# Altium schematic sheet EVB-NORA-B2_SCHEM_0004_Connectors_Memory.SchDoc(EVB-NORA-B2_SCHEM_0004_Connectors_Memory)
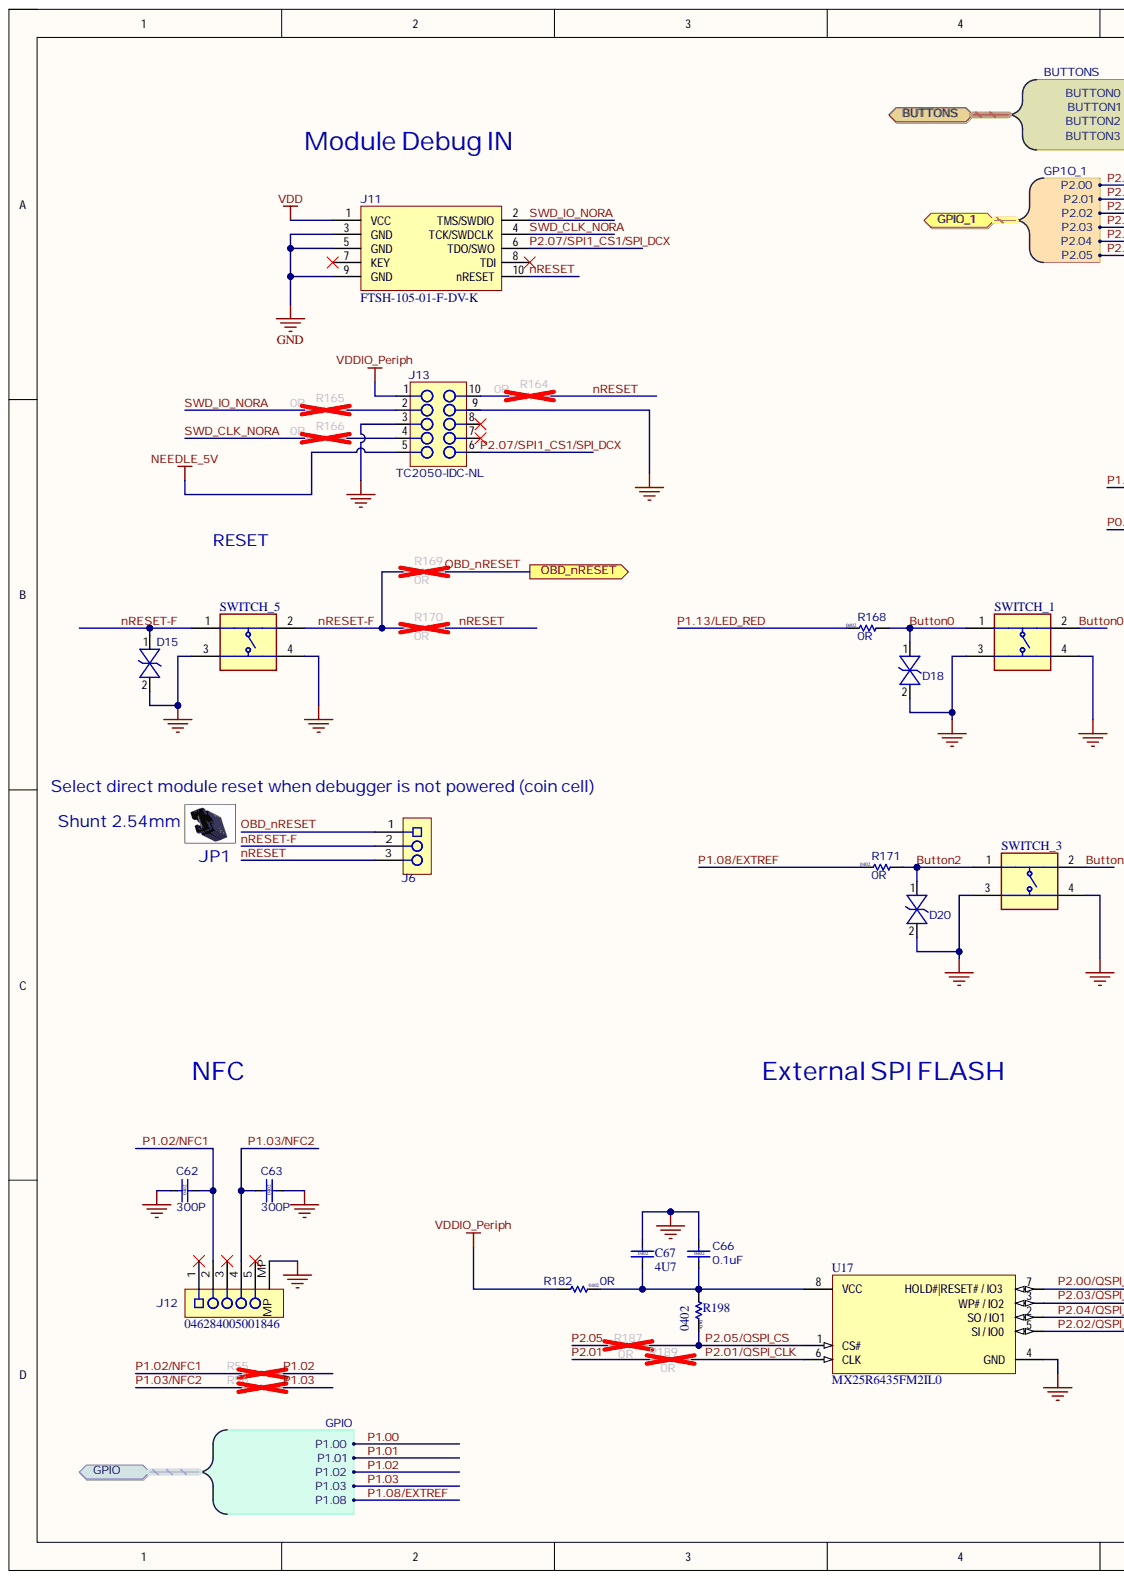
[diagram p0: EVB-NORA-B2_SCHEM_0004_Connectors_Memory.SchDoc, left]
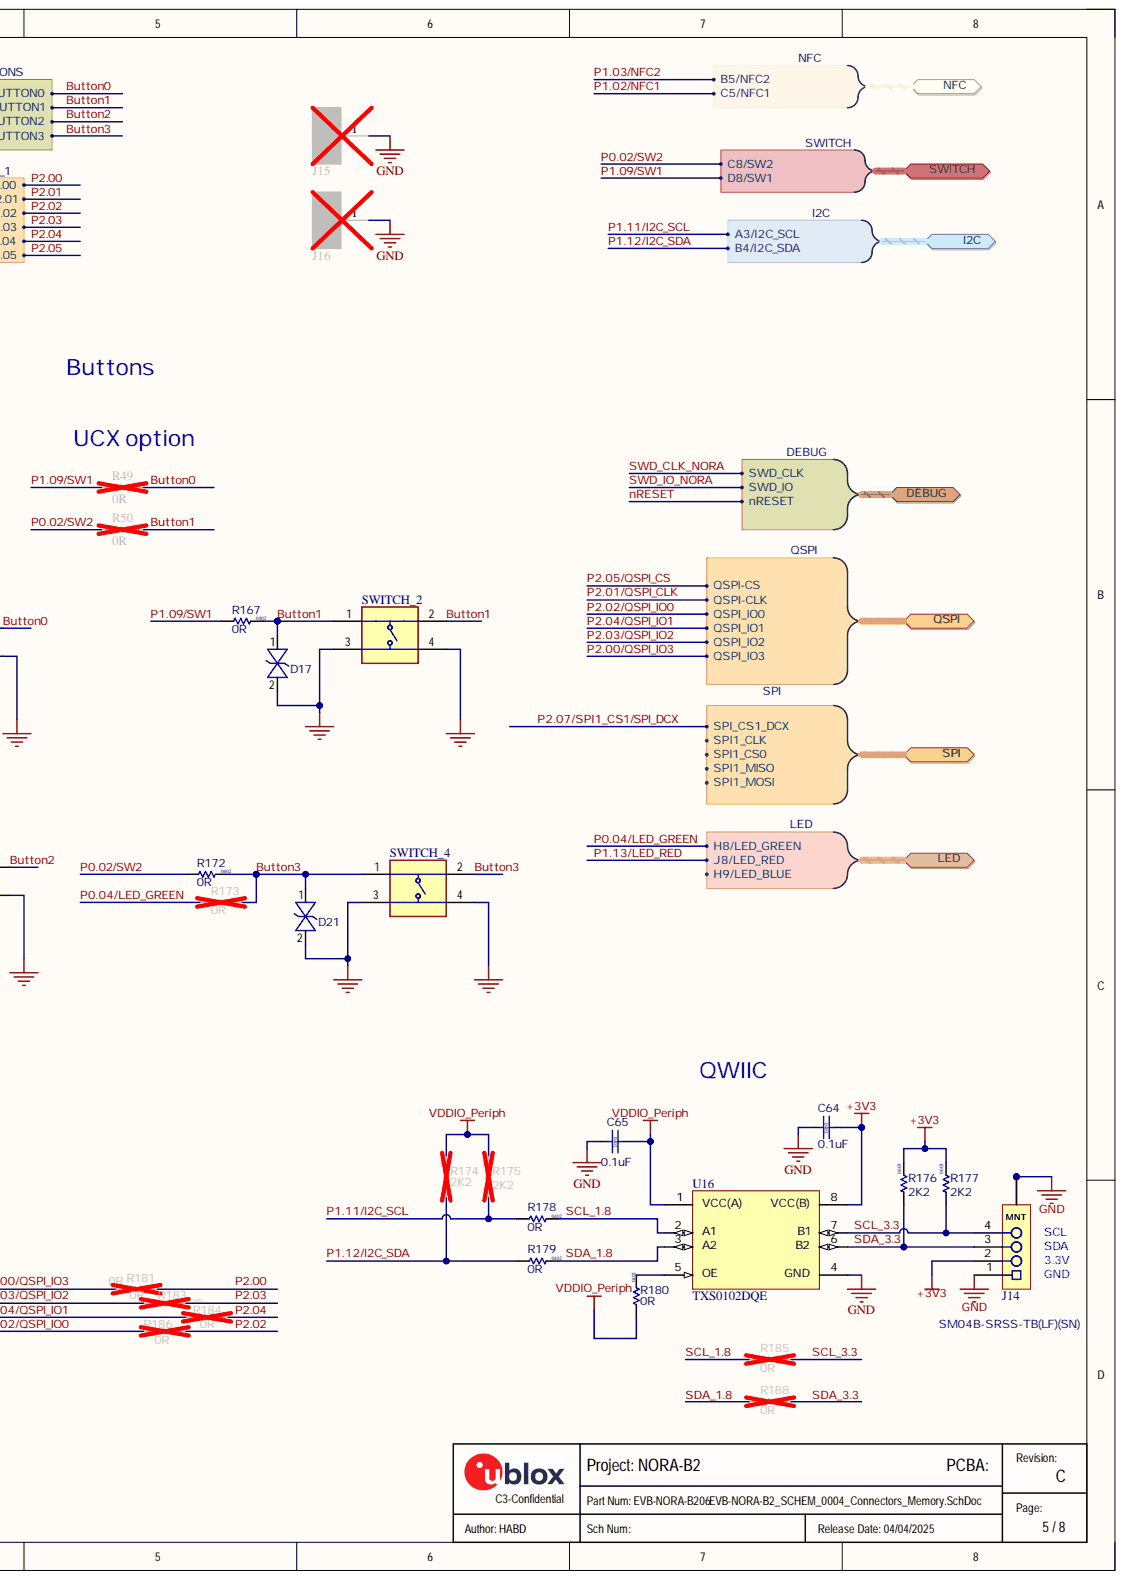
[diagram p1: EVB-NORA-B2_SCHEM_0004_Connectors_Memory.SchDoc, right]

## Parts
C62  value 300P  Size: 0402  [Cap 0402 HD]  (hidden comment: UBXH01-0000594)
C63  value 300P  Size: 0402  [Cap 0402 HD]  (hidden comment: UBXH01-0000594)
C64  value 0.1uF  Size: 0402  [Cap 0402 HD]  (hidden comment: 120-000160.1uF)
C65  value 0.1uF  Size: 0402  [Cap 0402 HD]  (hidden comment: 120-000160.1uF)
C66  value 0.1uF  Size: 0402  [Cap 0402 HD]  (hidden comment: 120-00016)
C67  value 4U7  Size: 0402  [Cap 0402 HD]
D15  110-00019  [SOD-923]  (value not drawn)
D17  110-00019  [SOD-923]  (value not drawn)
D18  110-00019  [SOD-923]  (value not drawn)
D20  110-00019  [SOD-923]  (value not drawn)
D21  110-00019  [SOD-923]  (value not drawn)
J6  UBXH04-0000792  [HDR-0.1P-3Pin-1Row-SMT]  (value not drawn)
J11  Manufacturer P/N: FTSH-105-01-F-DV-K  [HDR.050P-10Pin-2x5-SMT_Key]  (hidden comment: 130-00099)
J12  value 046284005001846  Manufacturer P/N: 046284005001846  [AVX/Kyocera - 046284005001846]  (hidden comment: UBXH04-0000798)
J13  TC2050-IDC-NL  [TC2050-IDC-NL]  (no BOM)
J14  Manufacturer Part Number 1: SM04B-SRSS-TB(LF)(SN)  [SM04B-SRSS-TB]  (hidden comment: UBXH04-0000797)
J15  ="Part Number"  [Harwin_0_MFG]
J16  ="Part Number"  [Harwin_0_MFG]
R49  value 0R  Size: 0402  [RES_0402_1100Lx600Wx400H_500x600Pad_400G_FLX]
R50  value 0R  Size: 0402  [RES_0402_1100Lx600Wx400H_500x600Pad_400G_FLX]
R55  Size: 0402  [RES 0402 HD]
R56  Size: 0402  [RES 0402 HD]
R164  value 0R  Size: 0402  [RES 0402 HD]
R165  value 0R  Size: 0402  [RES 0402 HD]
R166  value 0R  Size: 0402  [RES 0402 HD]
R167  value 0R  Size: 0402  [RES 0402 HD]  (hidden comment: UBXH18-0003002)
R168  value 0R  Size: 0402  [RES 0402 HD]
R169  value 0R  Size: 0402  [RES 0402 HD]
R170  value 0R  Size: 0402  [RES 0402 HD]
R171  value 0R  Size: 0402  [RES 0402 HD]  (hidden comment: UBXH18-0003002)
R172  value 0R  Size: 0402  [RES 0402 HD]
R173  value 0R  Size: 0402  [RES 0402 HD]  (hidden comment: UBXH18-0003002)
R174  value 2K2  Size: 0603  [RES_0603_1700Lx950Wx600H_600x1000Pad_800G_FLX]
R175  value 2K2  Size: 0603  [RES_0603_1700Lx950Wx600H_600x1000Pad_800G_FLX]
R176  value 2K2  Size: 0603  [RES_0603_1700Lx950Wx600H_600x1000Pad_800G_FLX]
R177  value 2K2  Size: 0603  [RES_0603_1700Lx950Wx600H_600x1000Pad_800G_FLX]
R178  value 0R  Size: 0402  [RES 0402 HD]
R179  value 0R  Size: 0402  [RES 0402 HD]
R180  value 0R  Size: 0402  [RES 0402 HD]
R181  value 0R  Size: 0402  [RES 0402 HD]
R182  value 0R  Size: 0402  [RES 0402 HD]
R183  value 0R  Size: 0402  [RES 0402 HD]
R184  value 0R  Size: 0402  [RES 0402 HD]
R185  value 0R  Size: 0402  [RES 0402 HD]
R186  value 0R  Size: 0402  [RES 0402 HD]
R187  value 0R  Size: 0402  [RES 0402 HD]
R188  value 0R  Size: 0402  [RES 0402 HD]
R189  value 0R  Size: 0402  [RES 0402 HD]
R198  Case/Package: 0x0y  Size: 0402  [RES 0402 HD]  (hidden comment: UBXH18-0000005)
SWITCH_1  (no value)  [PTS810SJG250SMTRLFS]
SWITCH_2  (no value)  [PTS810SJG250SMTRLFS]
SWITCH_3  (no value)  [PTS810SJG250SMTRLFS]
SWITCH_4  (no value)  [PTS810SJG250SMTRLFS]
SWITCH_5  (no value)  [PTS810SJG250SMTRLFS]
U16  value TXS0102DQE  Manufacturer P/N: TXS0102DQE  [DQE0008A]
U17  Manufacturer P/N: MX25R6435FM2IL0  [SOIC-8]

## Ports
BUTTONS, BUTTONS.BUTTON0, BUTTONS.BUTTON1, BUTTONS.BUTTON2, BUTTONS.BUTTON3, DEBUG, DEBUG.nRESET, DEBUG.SWD_CLK, DEBUG.SWD_IO, GPIO, GPIO.P1.00, GPIO.P1.01, GPIO.P1.02, GPIO.P1.03, GPIO.P1.08, GPIO_1, GPIO_1.P2.00, GPIO_1.P2.01, GPIO_1.P2.02, GPIO_1.P2.03, GPIO_1.P2.04, GPIO_1.P2.05, I2C, I2C.A3/I2C_SCL, I2C.B4/I2C_SDA, LED, LED.H8/LED_GREEN, LED.H9/LED_BLUE, LED.J8/LED_RED, NFC, NFC.B5/NFC2, NFC.C5/NFC1, OBD_nRESET, QSPI, QSPI.QSPI-CLK, QSPI.QSPI-CS, QSPI.QSPI_IO0, QSPI.QSPI_IO1, QSPI.QSPI_IO2, QSPI.QSPI_IO3, SPI, SPI.SPI1_CLK, SPI.SPI1_CS0, SPI.SPI1_MISO, SPI.SPI1_MOSI, SPI.SPI_CS1_DCX, SWITCH, SWITCH.C8/SW2, SWITCH.D8/SW1

## Nets
+3V3: C64.1 J14.2 R176.2 R177.2 U16.8(VCC(B))
GND: C62.1 C63.2 C64.2 C65.2 C66.1 C67.1 D15.2 D17.2 D18.2 D20.2 D21.2 J11.3(GND) J11.5(GND) J11.9(GND) J12.MP J13.3 J13.9 J14.1 J14.M1 J14.M2 J15.1 J16.1 SWITCH_1.3 SWITCH_1.4 SWITCH_2.3 SWITCH_2.4 SWITCH_3.3 SWITCH_3.4 SWITCH_4.3 SWITCH_4.4 SWITCH_5.3 SWITCH_5.4 U16.4(GND) U17.4(GND)
NEEDLE_5V: J13.5
VDD: J11.1(VCC)
VDDIO_Periph: C65.1 J13.1 R174.2 R175.2 R180.2 R182.1 U16.1(VCC(A))
Button0: D18.1 R49.2 R168.1 SWITCH_1.1 SWITCH_1.2 ; port BUTTONS
Button1: D17.1 R50.2 R167.1 SWITCH_2.1 SWITCH_2.2 ; port BUTTONS
Button2: D20.1 R171.1 SWITCH_3.1 SWITCH_3.2 ; port BUTTONS
Button3: D21.1 R172.1 R173.1 SWITCH_4.1 SWITCH_4.2 ; port BUTTONS
nRESET: J6.3 J11.10(nRESET) R164.1 R170.1 ; port DEBUG
nRESET-F: D15.1 J6.2 R169.2 R170.2 SWITCH_5.1 SWITCH_5.2
OBD_nRESET: J6.1 R169.1 ; port OBD_nRESET
P0.02/SW2: R50.1 R172.2 ; port SWITCH
P0.04/LED_GREEN: R173.2 ; port LED
P1.02: R55.1 ; port GPIO
P1.02/NFC1: C62.2 J12.2 R55.2 ; port NFC
P1.03: R56.1 ; port GPIO
P1.03/NFC2: C63.1 J12.4 R56.2 ; port NFC
P1.08/EXTREF: R171.2 ; port GPIO
P1.09/SW1: R49.1 R167.2 ; port SWITCH
P1.11/I2C_SCL: R175.1 R178.2 ; port I2C
P1.12/I2C_SDA: R174.1 R179.2 ; port I2C
P1.13/LED_RED: R168.2 ; port LED
P2.00: R181.1 ; port GPIO_1
P2.00/QSPI_IO3: R181.2 U17.7(HOLD#|RESET#_/_IO3) ; port QSPI
P2.01: R189.2 ; port GPIO_1
P2.01/QSPI_CLK: R189.1 U17.6(CLK) ; port QSPI
P2.02: R186.1 ; port GPIO_1
P2.02/QSPI_IO0: R186.2 U17.5(SI_/_IO0) ; port QSPI
P2.03: R183.1 ; port GPIO_1
P2.03/QSPI_IO2: R183.2 U17.3(WP#_/_IO2) ; port QSPI
P2.04: R184.1 ; port GPIO_1
P2.04/QSPI_IO1: R184.2 U17.2(SO_/_IO1) ; port QSPI
P2.05: R187.2 ; port GPIO_1
P2.05/QSPI_CS: R187.1 R198.2 U17.1(CS#) ; port QSPI
P2.07/SPI1_CS1/SPI_DCX: J11.6(TDO/SWO) J13.6 ; port SPI
SCL_1.8: R178.1 R185.2 U16.2(A1)
SCL_3.3: J14.4 R177.1 R185.1 U16.7(B1)
SDA_1.8: R179.1 R188.2 U16.3(A2)
SDA_3.3: J14.3 R176.1 R188.1 U16.6(B2)
SWD_CLK_NORA: J11.4(TCK/SWDCLK) R166.2 ; port DEBUG
SWD_IO_NORA: J11.2(TMS/SWDIO) R165.2 ; port DEBUG
NetC66_2: C66.2 C67.2 R182.2 R198.1 U17.8(VCC)
NetJ13_2: J13.2 R165.1
NetJ13_4: J13.4 R166.1
NetJ13_10: J13.10 R164.2
NetR180_1: R180.1 U16.5(OE)
N00002: ; port SPI
N00004: ; port SPI
N00006: ; port SPI
N00008: ; port SPI
N00009: ; port LED
P1.00: ; port GPIO
P1.01: ; port GPIO

Not connected: J11 7(KEY) 8(TDI); J12 1 3 5; J13 7 8

## Library data of the parts
C62 C63 = UBXH01-0000594: CAP CER C0G 0402 300P 50V; Manufacturer: Murata Manufacturing Company, Ltd.; Manufacturer P/N: GRM1555C1H301JA01D; Database Table Name: Passive - Capacitor; Editor: eric.moog; Env: ROHS; Mfg P/N Released: No; Mfg P/N Split: 100; Mfg P/N Status: Active; Perferred: PREF; Permitted Use: Volume Products; Component Kind: Standard; Library Name: UBX_Parts_DB_Lib.DbLib; Max Operating Temp: 125°C; Max Voltage: 50V; Min Operating Temp: -55°C; Part Number: UBXH01-0000594; Part Type: Capacitor; RefDes: C; Signal Integrity: Cap Semi; Simulation: CAP; UBX Wiki: https://wiki.u-blox.com/bin/view/Operations/PartDetails?index=38856
C64-C66 = 120-00016: Cap, Ceramic 0.1uF 10V 10% X5R 0402; Manufacturer: Murata Electronics North America; Manufacturer P/N: GRM155R61A104KA01J; Part Name: CAP CER 0.1UF 10V 10% X5R 0402; Component Mounting Type: SMT; Database Table Name: Ceramic Capacitor; Item_Phase: Production; Tolerance: +/- 10%; Use in new designs: Yes; Arena Link: https://app.bom.com/a/GYHPV2L2L43KRMBK?v=spec.summary; Component Kind: Standard; Height: 0.55mm; Manufacturer 2: Yageo; Manufacturer P/N 2: CC0402KRX5R6BB104; Part Number: 120-00016; Part_Type: Ceramic Capacitor; Pins: 2; Revision: 0A; Signal Integrity: Cap Semi; Simulation: CAP; Supplier 1: Digi-Key; Supplier 2: Digi-Key; Supplier Part Number 1: 490-6297-1-ND; Supplier Part Number 2: 311-1336-1-ND; Temperature Coefficient: X5R; Voltage: 10V; Library Name: Arena_Parts_DB_Lib.DbLib
C67 = UBXH01-0000243: CAP CER X5R 0402 4U7 20% 10V; Manufacturer: Murata Manufacturing Company, Ltd.; Manufacturer P/N: GRM155R61A475MEAA; Database Table Name: Passive - Capacitor; Editor: martin.pilik; Env: GREEN; Mfg P/N Released: Yes; Mfg P/N Split: 100; Mfg P/N Status: Active; Perferred: PREF; Permitted Use: Volume Products; Released by: fabrice.bret-dibat; Comment: ="Part Number" + Value + DNP; Component Kind: Standard; Library Name: UBX_Parts_DB_Lib.DbLib; Max Operating Temp: 85°C; Max Voltage: 10V; Mfg P/N Datasheet: https://wiki.u-blox.com/bin/view/Generic/Docs/DocumentSummary?index=24302; Min Operating Temp: -40°C; Part Number: UBXH01-0000243; Part Type: Capacitor; RefDes: C; Release Data: 2021-05-10 12:57:47.325652; Signal Integrity: Cap Semi; Simulation: CAP; Tolerance: 20%; UBX Wiki: https://wiki.u-blox.com/bin/view/Operations/PartDetails?index=24303
D15 D17 D18 D20 D21 = 110-00019: ESD9B3.3ST5G; Manufacturer: ON Semiconductor; Part Name: Diode TVS 3.3V SOD-923; Body Size: 0.8mm x 0.6mm; Component Mounting Type: SMT; Database Table Name: Diode; Diode Type: TVS; Item_Phase: Preliminary; Use in new designs: Yes; Voltage: 3.3V; Arena Link: https://app.bom.com/a/4M5DJQ9Q9SR7DV4H?v=spec.summary; Component Kind: Standard; Height: 0.4mm; Manufacturer P/N: ESD9B3.3ST5G; MFG Package: SOD-923; Package Type: SOD; Part Number: 110-00019; Part_Type: Diode; Pins: 2; Supplier 1: Digi-Key; Supplier Part Number 1: ESD9B3.3ST5GOSCT-ND; Library Name: Arena_Parts_DB_Lib.DbLib
J6 = UBXH04-0000792: 1-ROW SMD CONN 100MIL GRID 3 -PIN 100V 3A; Manufacturer: HARWiN; Manufacturer P/N: M20-8770342; Database Table Name: Electromechanical - Connector; Editor: eric.moog; Env: ROHS; Mfg P/N Released: No; Mfg P/N Split: 100; Mfg P/N Status: Active; Permitted Use: Support Products; Value: M20-8770342; Component Kind: Standard; Library Name: UBX_Parts_DB_Lib.DbLib; Max Current: 3A; Max Operating Temp: 105°C; Max Voltage: 100V; Mfg P/N Datasheet: https://wiki.u-blox.com/bin/view/Generic/Docs/DocumentSummary?index=28457; Min Operating Temp: -40°C; Part Number: UBXH04-0000792; Part Type: Connector; Perferred: N/A; RefDes: J; UBX Wiki: https://wiki.u-blox.com/bin/view/Operations/PartDetails?index=38569
J11 = 130-00099: Header 0.05" 10Pin 2Row SMD Black; Manufacturer: Samtec; Part Name: CON HDR 0.05" 10Pin 2Row SMD Black; Component Mounting Type: SMT; Database Table Name: Connector; Item_Phase: Pre-Production; Keyed: Yes; Locking: No; Pin Pitch: 0.050"; Rows: 2; Arena Link: https://app.bom.com/a/5N6EKRARATS7TSHL?v=spec.summary; Color: Black; Component Kind: Standard; Connector Type: Header; Height: 6mm; Length: 12.75mm; Part Number: 130-00099; Part_Type: Connector; Pins: 10; Revision: 1; Supplier 1: Digi-Key; Supplier Part Number 1: SAM8796-ND; Use in new designs: Yes; Width: 4.78; Library Name: Arena_Parts_DB_Lib.DbLib
J12 = UBXH04-0000798: 0.50MM PITCH SMD RA FFC FPC CONN 5 -PIN 50V 400mA; Manufacturer: AVX; Manufacturer 1: KYOCERA AVX; Part Name: CON FFC/FPC 0.50mm 5Pos R/A; Part_Type: Connector; Component Mounting Type: SMT; Database Table Name: Electromechanical - Connector; Editor: eric.moog; Env: ROHS; Item_Phase: Preliminary; Keyed: No; Mfg P/N Split: 100; Mfg P/N Status: Active; Perferred: PREF; Permitted Use: Support Products; Pin Pitch: 0.5mm; Rows: 1; Use in new designs: Yes; Color: White; Component Kind: Standard; Connector Type: FPC; Height: 1.3mm; Length: 4.05mm; Library Name: UBX_Parts_DB_Lib.DbLib; Locking: No; Manufacturer Part Number 1: 046284005001846; Max Current: 400mA; Max Operating Temp: 85°C; Max Voltage: 50V; Mfg P/N Datasheet: https://wiki.u-blox.com/bin/view/Generic/Docs/DocumentSummary?index=38862; Mfg P/N Released: No; Min Operating Temp: -40°C; Part Number: UBXH04-0000798; Part Type: Connector; Pins: 5; RefDes: J; UBX Wiki: https://wiki.u-blox.com/bin/view/Operations/PartDetails?index=38822; Voltage: 50V; Width: 4.4mm
J13 = TC2050-IDC-NL: CON PADS FOR CBL PLUG-OF-NAILS 10-PIN NO LEGS; Manufacturer: TAG Connect; Added By: Paul B; Database Table Name: Testpoints & Pads; Added Date: 12/3/2015; DNP: DNP; Height: 0; Manufacturer P/N: TC2050-IDC-NL; Part Number: TC2050-IDC-NL; Size: 6.1mm x 9.9mm; Library Name: LEGACY_Rigado_AltiumLib.DbLib
J14 = UBXH04-0000797: CONN JST SH SERIES SMT 4 POS MALE 1MM RA 50V 1A; Manufacturer 1: JST Sales America Inc.; Part Name: CONN HEADER SMD R/A 4POS 1MM; Database Table Name: Electromechanical - Connector; Editor: eric.moog; Env: ROHS; Item Phase: In Production; Mfg P/N Released: No; Mfg P/N Split: 100; Mfg P/N Status: Active; Perferred: PREF; Permitted Use: Support Products; UBX Code: None; Use in new designs: Yes; Value: SM04B-SRSS-TB; Component Kind: Standard; Diameter: None; Height: 2.9mm; Library Name: UBX_Parts_DB_Lib.DbLib; Manufacturer: JST; Manufacturer 2: None; Manufacturer P/N: SM04B-SRSS-TB; Manufacturer Part Number 2: None; Max Current: 1A; Max Operating Temp: 85°C; Max Voltage: 50V; Mfg P/N Datasheet: https://wiki.u-blox.com/bin/view/Generic/Docs/DocumentSummary?index=4533; Min Operating Temp: -30°C; Part Number: UBXH04-0000797; Part Type: Connector; Pins: 4; RefDes: J; Revision: 0A; Supplier 1: None; Supplier 2: None; Supplier Part Number 1: None; Supplier Part Number 2: None; UBX Wiki: https://wiki.u-blox.com/bin/view/Operations/PartDetails?index=38779; Voltage: None
J15 J16 = S1751-46R: Component Kind: Standard; Library Name: Conn_Harwin_S1751-46R_eec.SchLib
R49 R50 R182 = UBXH18-0003002: RES THICK FILM CHIP 0402 0R 0 100mW; Manufacturer: KOA; Manufacturer P/N: RK73Z1ETTD; Database Table Name: Passive - Resistor; Editor: charlotte.biber; Env: ROHS; Mfg P/N Released: Yes; Mfg P/N Split: 100; Mfg P/N Status: NRND; Perferred: PREF; Permitted Use: Volume Products; Released by: peter.mueller; Tolerance: 0; Comment: = "Part Number" + Value + DNP; Component Kind: Standard; Library Name: UBX_Parts_DB_Lib.DbLib; Manufacturer 2: YAGEO; Manufacturer P/N 2: 2322_705_91001_L; Max Power: 100mW; Mfg P/N Released 2: Yes; Mfg P/N Split 2: 0; Part Number: UBXH18-0003002; Part Type: Resistor; RefDes: R; Signal Integrity: Res; Simulation: RESISTOR; UBX Wiki: https://wiki.u-blox.com/bin/view/Operations/PartDetails?index=6611
  R49 R50: Mfg P/N Status 2: Obsolete; Release Data: 2012-06-05T09:49:55
  R182: Mfg P/N Status 2: NRND; Release Data: 2012-06-05 09:49:55.978616
R55 R56 R164-R173 R178-R181 R183-R189 = UBXH18-0003002: RES THICK FILM CHIP 0402 0R 0 100mW; Manufacturer: KOA; Manufacturer P/N: RK73Z1ETTP; Database Table Name: Passive - Resistor; Editor: charlotte.biber; Env: ROHS; Mfg P/N Released: Yes; Mfg P/N Split: 100; Mfg P/N Status: NRND; Perferred: PREF; Permitted Use: Volume Products; Released by: peter.mueller; Tolerance: 0; Component Kind: Standard; Library Name: UBX_Parts_DB_Lib.DbLib; Manufacturer 2: YAGEO; Manufacturer P/N 2: 2322_705_91001_L; Max Power: 100mW; Mfg P/N Released 2: Yes; Mfg P/N Split 2: 0; Mfg P/N Status 2: NRND; Part Number: UBXH18-0003002; Part Type: Resistor; RefDes: R; Release Data: 2012-06-05 09:49:55.978616; Signal Integrity: Res; Simulation: RESISTOR; UBX Wiki: https://wiki.u-blox.com/bin/view/Operations/PartDetails?index=6611
  R55 R56: Comment: = "Part Number" + Value + DNP; Value: 0R
  R164-R166 R168-R170 R172 R178-R181 R183-R189: Comment: = "Part Number" + Value + DNP
R174-R177 = UBXH18-0007081: RES THICK FILM CHIP 0603 2K2 5% 100mW; Manufacturer: YAGEO; Manufacturer P/N: 2322_702_81222_L; Database Table Name: Passive - Resistor; Editor: roland.rust; Env: ROHS; Mfg P/N Released: Yes; Mfg P/N Split: 100; Mfg P/N Status: Obsolete; Perferred: PREF; Permitted Use: Volume Products; Comment: = "Part Number" + Value + DNP; Component Kind: Standard; Library Name: UBX_Parts_DB_Lib.DbLib; Manufacturer 2: YAGEO; Manufacturer P/N 2: RC0603JR-072K2L; Max Power: 100mW; Mfg P/N Datasheet 2: https://wiki.u-blox.com/bin/view/Generic/Docs/DocumentSummary?index=8241; Mfg P/N Released 2: Yes; Mfg P/N Split 2: 0; Mfg P/N Status 2: Active; Part Number: UBXH18-0007081; Part Type: Resistor; RefDes: R; Release Data: 2007-04-26T15:06:13; Signal Integrity: Res; Simulation: RESISTOR; Tolerance: 5%; UBX Wiki: https://wiki.u-blox.com/bin/view/Operations/PartDetails?index=6711; Released by: roland.rust
R198 = UBXH18-0000005: RES THICK FILM CHIP 0402 100K 1% 63mW; Manufacturer: KOA; Manufacturer P/N: RK73H1ETTP1003F; Database Table Name: Passive - Resistor; Editor: dario.antonaz; Env: ROHS; Mfg P/N Released: Yes; Mfg P/N Split: 100; Mfg P/N Status: Active; Permitted Use: Volume Products; Released by: sandro.sestan; Component Kind: Standard; Library Name: UBX_Parts_DB_Lib.DbLib; Manufacturer 2: YAGEO; Manufacturer 3: ROHM; Manufacturer P/N 2: RC0402FR-07100KL; Manufacturer P/N 3: MCR01MZPF1003; Max Power: 63mW; Mfg P/N Datasheet: https://wiki.u-blox.com/bin/view/Generic/Docs/DocumentSummary?index=8222; Mfg P/N Datasheet 2: https://wiki.u-blox.com/bin/view/Generic/Docs/DocumentSummary?index=29373; Mfg P/N Datasheet 3: https://wiki.u-blox.com/bin/view/Generic/Docs/DocumentSummary?index=8186; Mfg P/N Released 2: Yes; Mfg P/N Released 3: Yes; Mfg P/N Split 2: 0; Mfg P/N Split 3: 0; Mfg P/N Status 2: Active; Mfg P/N Status 3: Obsolete; Part Number: UBXH18-0000005; Part Type: Resistor; Perferred: N/A; RefDes: R; Release Data: 2009-11-30T11:05:22; Signal Integrity: Res; Simulation: RESISTOR; Tolerance: 1%; UBX Wiki: https://wiki.u-blox.com/bin/view/Operations/PartDetails?index=8114; Value: 100K
SWITCH_1-SWITCH_5 = PTS810SJK250SMTRLFS: Switch; Manufacturer_Name: C & K COMPONENTS; Component Kind: Standard; Datasheet Link: https://www.ckswitches.com/media/1476/pts810.pdf; Library Name: SamacSys.SchLib; Manufacturer_Part_Number: PTS810SJK250SMTRLFS; Mouser Part Number: 611-PTS810SJK250SMTR; Mouser Price/Stock: https://www.mouser.co.uk/ProductDetail/CK/PTS810SJK250SMTRLFS?qs=UxeAxwACbqlfHzoZSrW3%2Fw%3D%3D
U16 = UBXH10-0000108: TXS0102 2-BIT BIDIRECTIONAL VOLTAGE-LEVEL TRANSLATOR X2SON-8 TXS0102DQE 5.5V; Manufacturer: Texas Instruments; Manufacturer Part Number 1: TXS0102DQET; Body Size: 1.9mm x 0.9mm; Current: None; Database Table Name: IC - Interface; Editor: eric.moog; Env: ROHS; Item Phase: In Production; Mfg P/N Released: No; Mfg P/N Split: 100; Mfg P/N Status: Active; MFG Package: DSBGA-8; Perferred: PREF; Permitted Use: Support Products; Single Source: Yes; Comment: = "Part Number" + DNP; Component Kind: Standard; Height: 0.65mm; Library Name: UBX_Parts_DB_Lib.DbLib; Manufacturer 1: Texas Instruments; Manufacturer 2: Texas Instruments; Manufacturer Part Number 2: TXS0102DQER; Max Operating Temp: 85°C; Max Voltage: 5.5V; Mfg P/N Datasheet: https://wiki.u-blox.com/bin/view/Generic/Docs/DocumentSummary?index=22177; Min Operating Temp: -40°C; Part Name: TXS0102DQE; Part Number: UBXH10-0000108; Part Type: Interface; Pins: 8; RefDes: U; Revision: 0A; UBX Code: None; UBX Wiki: https://wiki.u-blox.com/bin/view/Operations/PartDetails?index=39049; Use in new designs: Yes; Voltage: N/A
U17 = UBXH13-0000149: MACRONIX MX25R6435FM2IL0 64MBIT SERIAL QUAD I/O SPI FLASH MEMORY 1.65 - 3.6V 8-SOP (200mil); Manufacturer 1: Macronix International Co.,Ltd.; Manufacturer Split 1: 100; Part Phase: Unreleased; Part Revision: 1; Body Size: 5.38mm x 5.33mm; Database Table Name: Integrated Circuit - Memory; Environmental Grade: RoHS; Interface: QSPI; Max Supply Voltage: 3.6; Memory Size: 64MB; Memory Speed: 80MHz; Memory Type: NOR FLASH; Min Supply Voltage: 1.65; Owner: Hozaifa Abdelgadir; Single Source: No; SMT Pitch: 1.27mm; Use in new designs: Yes; Automotive: No; Case/Package: 8-SOP; Comment: = "Part Number" + DNP; Component Kind: Standard; ComponentLink1Description: Arena Link; ComponentLink1Url: https://app.bom.com/7P9SXLTVPRAI1EPKZK38; Height: 2.16; Manufacturer Part Number 1: MX25R6435FM2IL0; Max Operating Temp: 85°C; Min Operating Temp: -40°C; Mounting Type: SMT; Part Name: MACRONIX MX25R6435FM2IL0 64MBIT SERIAL QUAD I/O SPI FLASH MEMORY 1.65 - 3.6V 8-SOP (200mil); Part Number: UBXH13-0000149; Part Status: Preferred; Part Type: Memory; Pins: 8; Thermal Pad: No; Volume Use: No; Library Name: UBX_Arena.DbLib
